# Altium schematic sheet CAN-Bus.SchDoc(CAN-Bus)
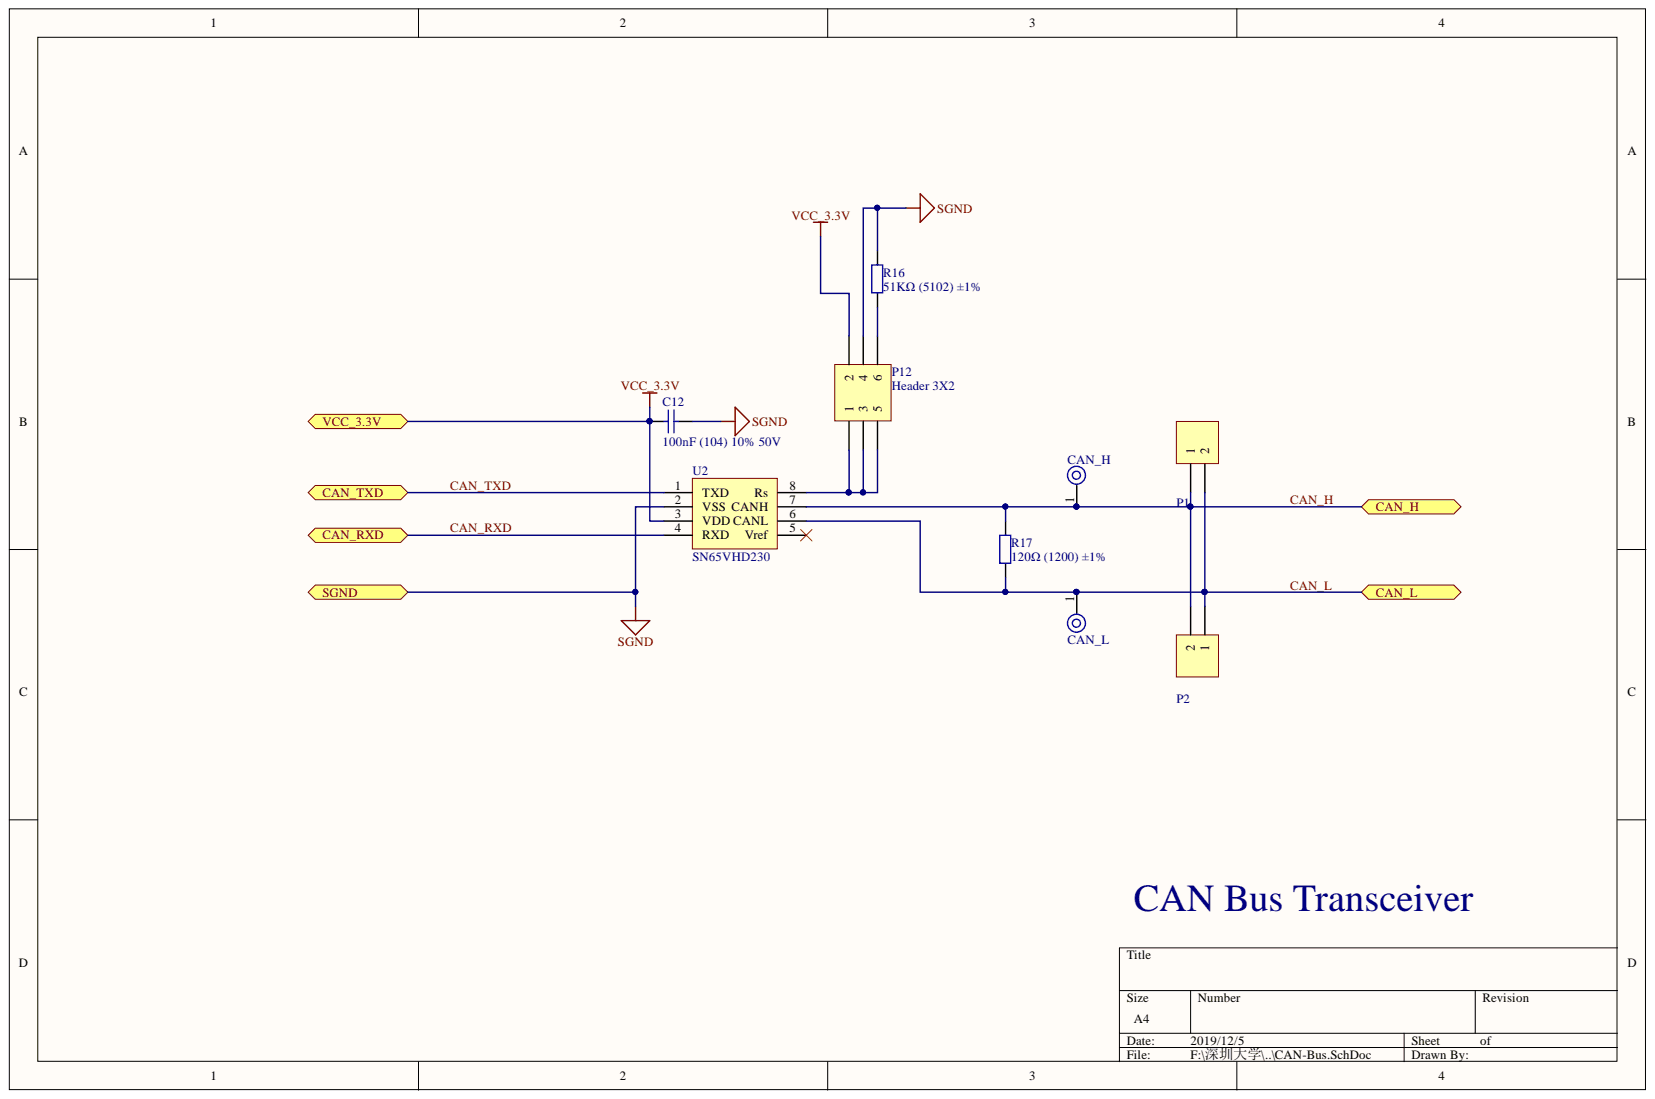
[diagram p0: CAN-Bus.SchDoc]

## Parts
C12  100nF (104) 10% 50V  [0603_C]
CAN_H  TestPoint  [TestPoint]  (value not drawn)
CAN_L  TestPoint  [TestPoint]  (value not drawn)
P1  Header 2  [HDR2X1_B1]  (value not drawn)
P2  Header 2  [HDR2X1_B1]  (value not drawn)
P12  Header 3X2  [HDR2X3]
R16  51KΩ (5102) ±1%  [0603_R]
R17  120Ω (1200) ±1%  [0603_R]
U2  SN65VHD230  [SOIC-8]

## Ports
CAN_H, CAN_L, CAN_RXD, CAN_TXD, SGND, VCC_3.3V

## Nets
CAN_H: CAN_H.1 P1.1 P2.2 R17.2 U2.7(CANH) ; port CAN_H
CAN_L: CAN_L.1 P1.2 P2.1 R17.1 U2.6(CANL) ; port CAN_L
CAN_RXD: U2.4(RXD) ; port CAN_RXD
CAN_TXD: U2.1(TXD) ; port CAN_TXD
SGND: C12.2 P12.4 R16.2 U2.2(VSS) ; port SGND
VCC_3.3V: C12.1 P12.2 U2.3(VDD) ; port VCC_3.3V
NetP12_1: P12.1 P12.3 P12.5 U2.8(Rs)
NetP12_6: P12.6 R16.1

Not connected: U2 5(Vref)

## Library data of the parts
C12 = 0603 100nF (104) 10% 50V: 贴片电容; SuppliersPartNumber: C14663; Supplier: LC; Package: 0603; Library Name: JLC Library.IntLib; ComponentLink1URL: http://www.szlcsc.com/product/details_15331.html; ComponentLink1Description: 供应商链接; Component Kind: Standard
CAN_H CAN_L = TestPoint: 信号测试点; Component Kind: Standard; Library Name: MPLM_Driver_V10A1.IntLib
P1 P2 = Header 2: 接插件; LatestRevisionNote: Re-released for DXP Platform.; LatestRevisionDate: 17-Jul-2002; Component Kind: Standard; Publisher: Altium Limited; Library Name: 接插件集成库.IntLib
P12 = Header 3X2: Header, 3-Pin, Dual row; Signal Integrity: Connector; Library Name: Miscellaneous Connectors.IntLib; LatestRevisionNote: Re-released for DXP Platform.; LatestRevisionDate: 17-Jul-2002; Component Kind: Standard; Publisher: Altium Limited
R16 = 0603 51KΩ (5102) 1%: 贴片电阻; SuppliersPartNumber: C23196; Supplier: LC; Package: 0603; Library Name: JLC Library.IntLib; ComponentLink1URL: http://www.szlcsc.com/product/details_23923.html; ComponentLink1Description: 供应商链接; Component Kind: Standard
R17 = 0603 120Ω (1200) 1%: 贴片电阻; SuppliersPartNumber: C22787; Supplier: LC; Package: 0603; Library Name: JLC Library.IntLib; ComponentLink1URL: http://www.szlcsc.com/product/details_23514.html; ComponentLink1Description: 供应商链接; Component Kind: Standard
U2 = SN65VHD230: Library Name: Common Device.IntLib; Component Kind: Standard
